# Altium schematic sheet Boost Converter.SchDoc
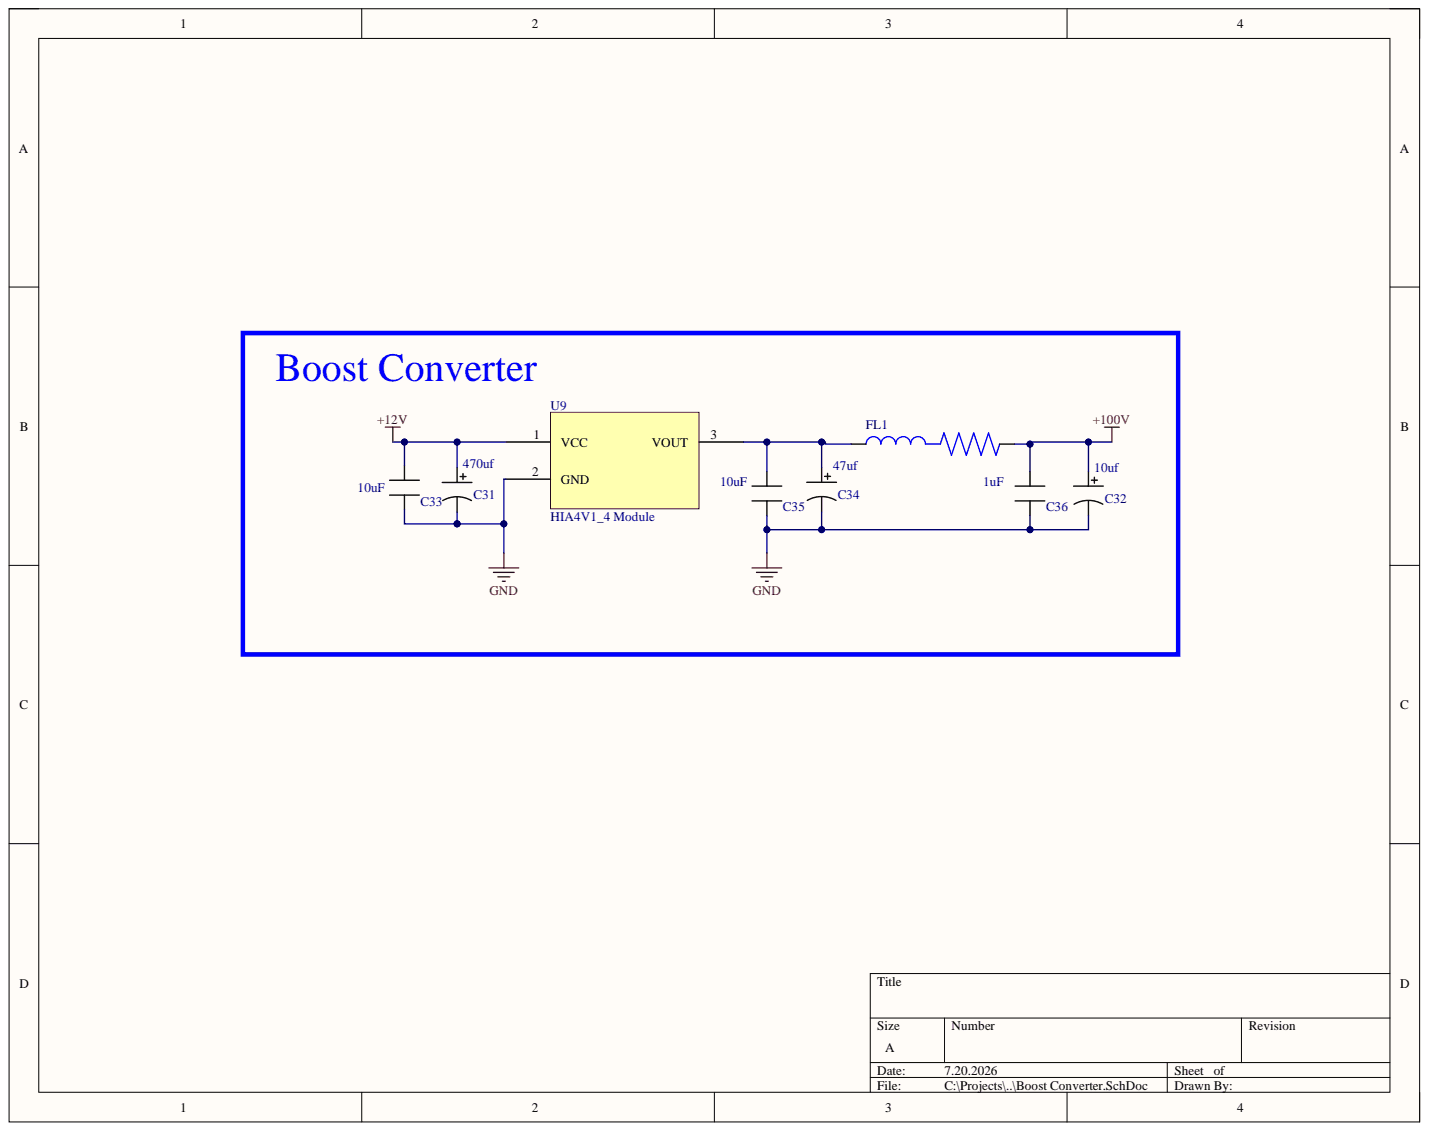
[diagram p0: Boost Converter.SchDoc]

## Parts
C31  470uf  [CAP_CAN_D10mm]
C32  10uf  [CAP_CAN_D10mm]
C33  10uF  [CAP_0805]
C34  47uf  [CAP_CAN_D5MM]
C35  10uF  [CAP_1206]
C36  1uF  [CAP_1206]
FL1  (no value)  [BEAD1206S601A40T]
U9  HIA4V1_4 Module  [HIA4V1_4]

## Nets
+12V: C31.1 C33.2 U9.1
+100V: C32.1 C36.2 FL1.2
GND: C31.2 C32.2 C33.1 C34.2 C35.1 C36.1 U9.2
NetC34_1: C34.1 C35.2 FL1.1 U9.3

## Library data of the parts
C31 C32 = CAP_CAN_D10mm: SMD Can Capacitor; 10mm Diameter; note: Original; Component Kind: Standard; Library Name: Passives.SchLib; sample link: https://www.lcsc.com/product-detail/Aluminum-Electrolytic-span-style-background-color-ff0-Capacitors-span-SMD_ROQANG-RVT2A470M1010_C335988.html
  C31: val: 470uf
  C32: val: 10uf
C33 = CAP_0805: note: any manufacturer; Component Kind: Standard; Description: CAP_0805; Library Name: Passives.SchLib; val: 10uF
C34 = CAP_CAN_D5mm: SMD Can Capacitor; 5mm Diameter; note: any manufacturer; Component Kind: Standard; Library Name: Passives.SchLib; sample link: https://www.lcsc.com/product-detail/C3357.html?s_z=n_10uF%2520SMD%2520Can%2520Capacitor%2520D5%2520RVT; val: 47uf
C35 C36 = CAP_1206: note: any manufacturer; Component Kind: Standard; Description: CAP_1206; Library Name: Passives.SchLib
  C35: val: 10uF
  C36: val: 1uF
FL1 = BEAD1206S601A40T: MF: Murata; MP: BLM03EB500SZ1D; Check_prices: https://www.snapeda.com/parts/BLM03EB500SZ1D/Murata/view-part/?ref=eda; Component Kind: Standard; Library Name: Passives.SchLib; Package: 0603 Murata; SnapEDA_Link: https://www.snapeda.com/parts/BLM03EB500SZ1D/Murata/view-part/?ref=snap
U9 = HIA4V1_4: Component Kind: Standard; Library Name: IC_Power.SchLib
